# Altium schematic sheet NU.N8051.EK02.001.SCHDOC
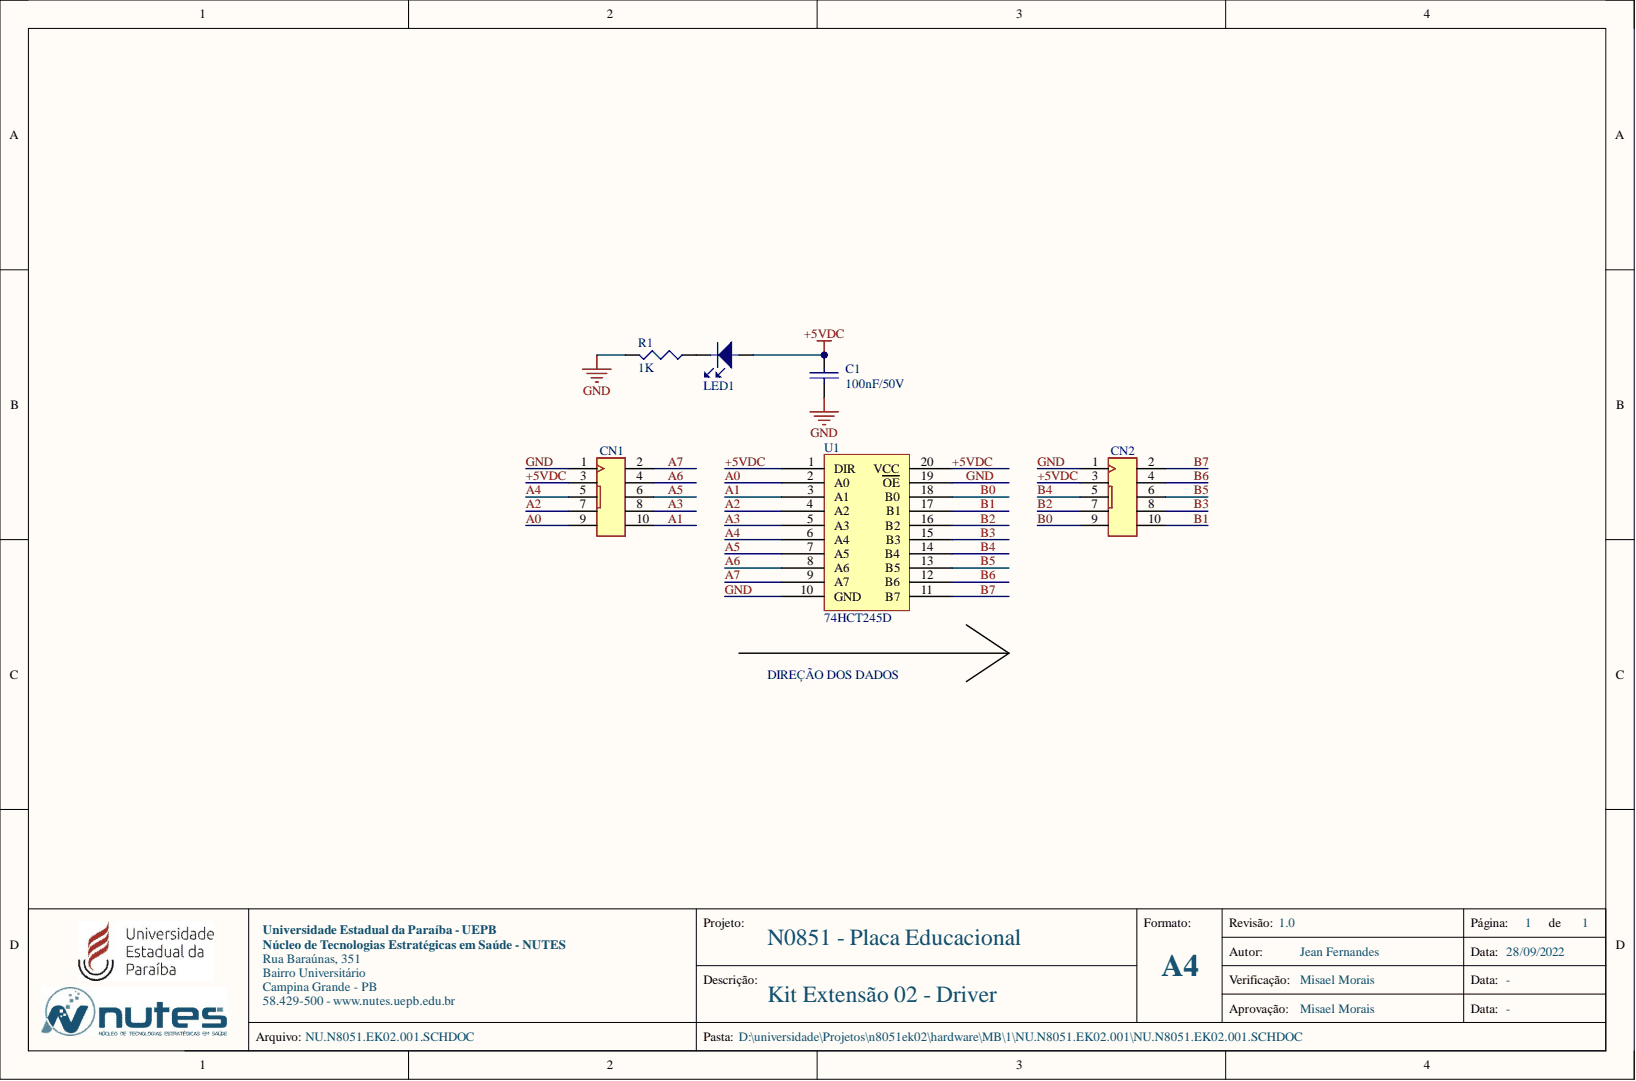
[diagram p0: NU.N8051.EK02.001.SCHDOC]

## Parts
C1  value 100nF/50V  [CAP-0603-IPC-MD]  (hidden comment: CAPACITOR)
CN1  IDCEC-10-LP  [IDCEC-10-LP]  (value not drawn)
CN2  IDCEC-10-LP  [IDCEC-10-LP]  (value not drawn)
LED1  DIODE - LED  [LED-0603-IPC-MD-RED]  (value not drawn)
R1  value 1K  [RES-0603-IPC-MD]  (hidden comment: RESISTOR)
U1  74HCT245D  [SOP127P1030X265-20M]

## Nets
+5VDC: C1.1 CN1.3 CN2.3 LED1.1 U1.1(DIR) U1.20(VCC)
A0: CN1.9 U1.2(A0)
A1: CN1.10 U1.3(A1)
A2: CN1.7 U1.4(A2)
A3: CN1.8 U1.5(A3)
A4: CN1.5 U1.6(A4)
A5: CN1.6 U1.7(A5)
A6: CN1.4 U1.8(A6)
A7: CN1.2 U1.9(A7)
B0: CN2.9 U1.18(B0)
B1: CN2.10 U1.17(B1)
B2: CN2.7 U1.16(B2)
B3: CN2.8 U1.15(B3)
B4: CN2.5 U1.14(B4)
B5: CN2.6 U1.13(B5)
B6: CN2.4 U1.12(B6)
B7: CN2.2 U1.11(B7)
GND: C1.2 CN1.1 CN2.1 R1.2 U1.10(GND) U1.19(OE)
NetLED1_2: LED1.2 R1.1

## Library data of the parts
C1 = CAPACITOR: General Purpose Capacitor; Manufacturer: Murata Electronics; Manufacturer Part Number: GRM188R71H104KA93D; Component Kind: Standard; Datasheet: https://datasheet.lcsc.com/lcsc/1810311126_Murata-Electronics-GRM188R71H104KA93D_C77055.pdf; Package: 0603; Price: 0.0049; Supplier: JLCPCB; Supplier Link: https://jlcpcb.com/; Supplier Part Number: C77055; Library Name: NUTES_GENERAL.SCHLIB
CN1 CN2 = IDCEC-10-LP: 2.54mm Straight 5 Push - Pull 2.54mm 2 Plugin,P=2.54mm IDC Connectors ROHS; Manufacturer: BOOMELE(Boom Precision Elec); Manufacturer Part Number: C5665; Value: C5665; Component Kind: Standard; Datasheet: https://datasheet.lcsc.com/lcsc/1810281612_BOOMELE-Boom-Precision-Elec-C5665_C5665.pdf; Package: IDC-TH_10P-P2.54_C5665; Price: 0.0731; Signal Integrity: Guessed Model; Supplier: JLCPCB; Supplier Link: https://jlcpcb.com/; Supplier Part Number: C5665; Library Name: NUTES_GENERAL.SCHLIB
LED1 = DIODE - LED: Colorless transparence yellow Plugin Light Emitting Diodes (LED) ROHS; Manufacturer: TOGIALED; Manufacturer Part Number: TJ-L5FYTXHTCGLFLC9Y-A5; Value: TJ-L5FYTXHTCGLFLC9Y-A5; Component Kind: Standard; Datasheet: https://datasheet.lcsc.com/lcsc/2006301912_TOGIALED-TJ-L5FYTXHTCGLFLC9Y-A5_C330770.pdf; Package: LED-TH; Price: 0.04; Supplier: JLCPCB; Supplier Link: https://jlcpcb.com/; Supplier Part Number: C330770; Library Name: NUTES_GENERAL.SCHLIB
R1 = RESISTOR: General Purpose Resistor; Manufacturer: Uni-royal; Manufacturer Part Number: 0603WAF5600T5E; Component Kind: Standard; Datasheet: https://datasheet.lcsc.com/lcsc/2206010116_UNI-ROYAL-Uniroyal-Elec-0603WAF5600T5E_C23204.pdf; Package: 0603; Price: 0.0011; Supplier: JLCPCB; Supplier Link: https://jlcpcb.com/; Supplier Part Number: C23204; Library Name: NUTES_GENERAL.SCHLIB
U1 = 74XXX245: Bus Transceivers Octal Bus Trnscvr With 3-State Outputs; Component Kind: Standard; Library Name: NUTES_GENERAL.SCHLIB
